# Altium schematic sheet IO.SchDoc(IO)
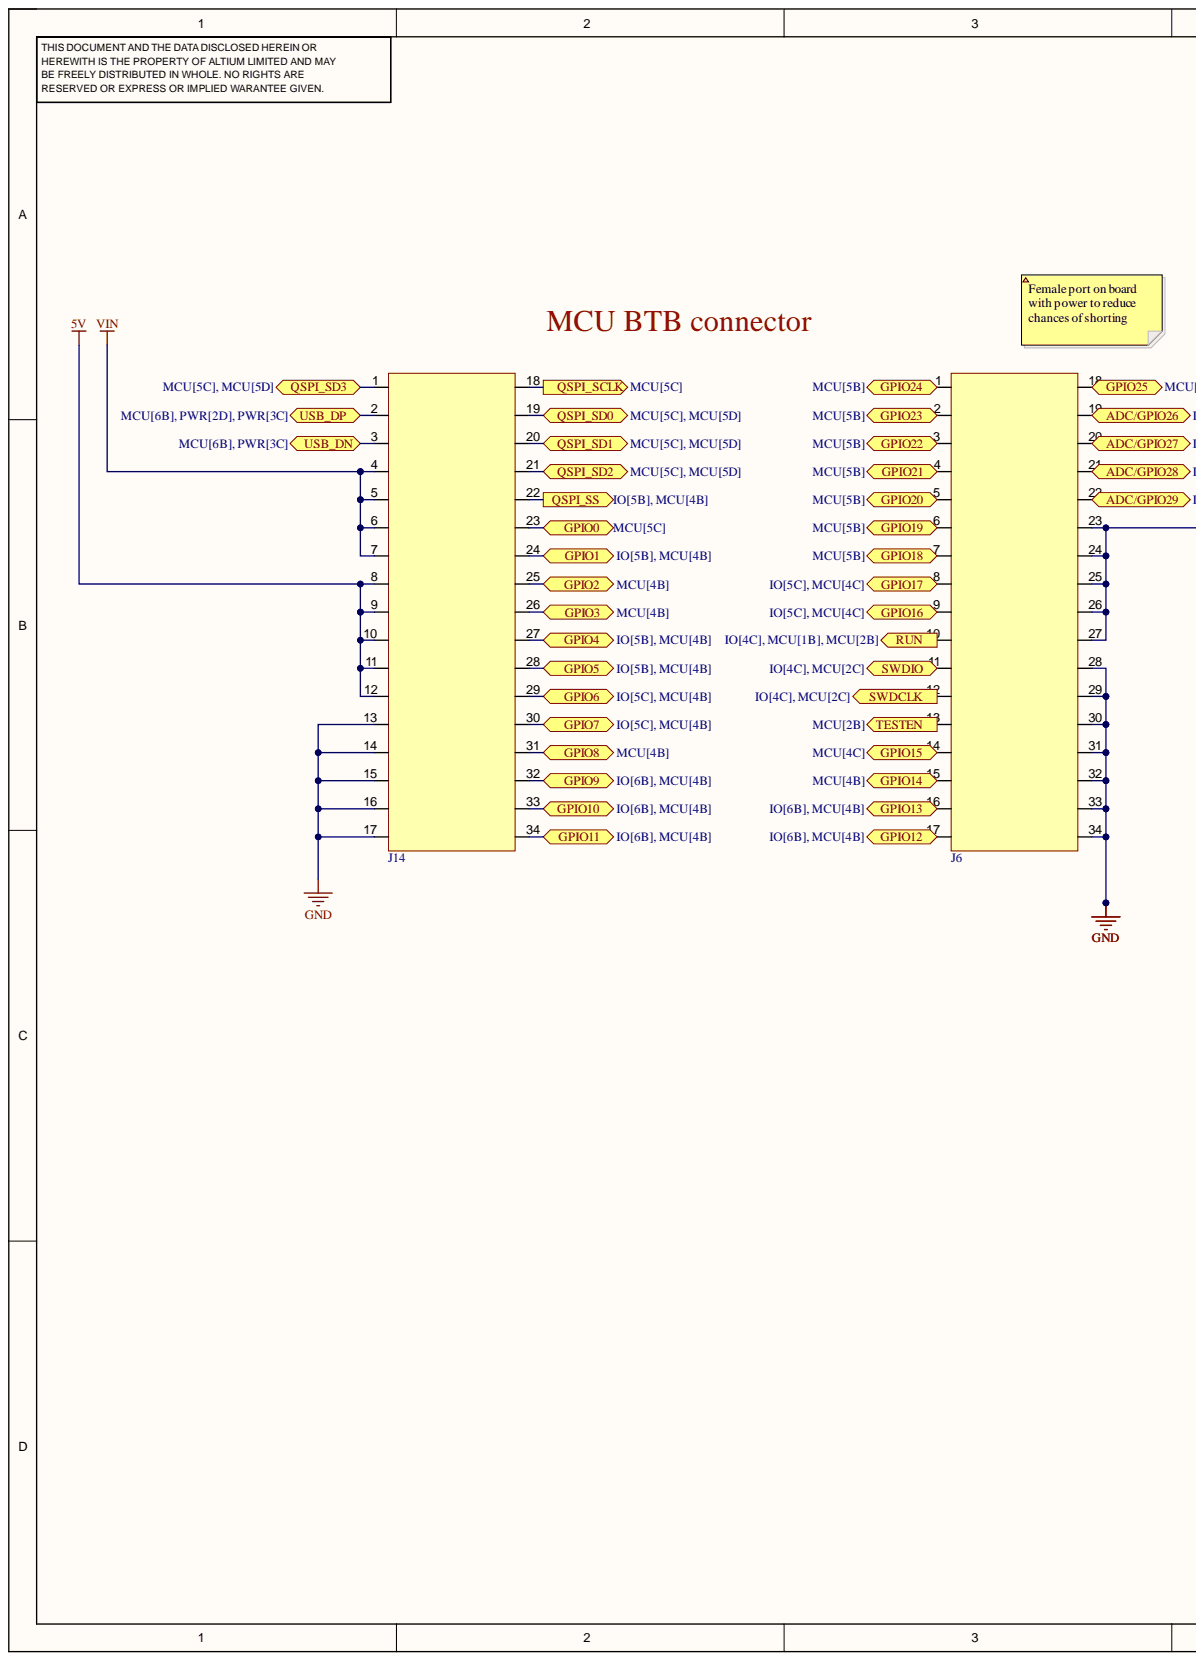
[diagram p0: IO.SchDoc, left]
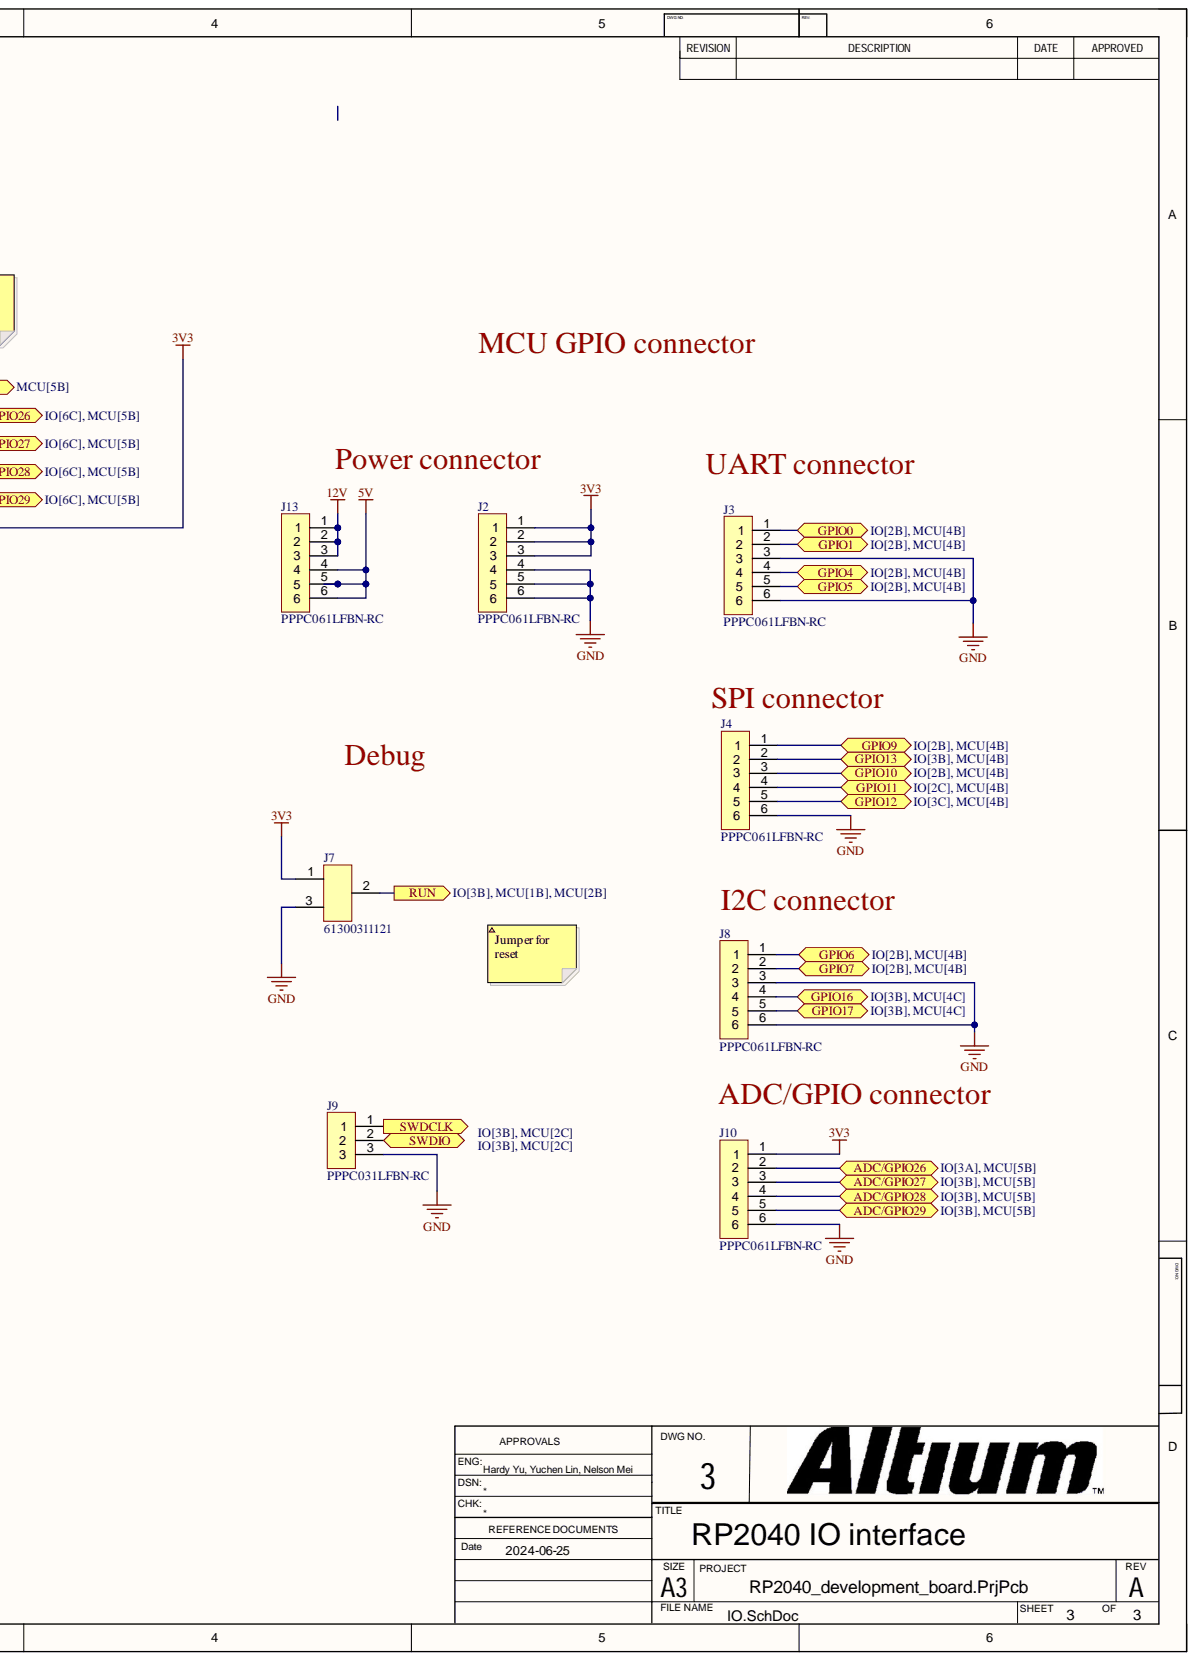
[diagram p1: IO.SchDoc, right]

## Parts
J2  PPPC061LFBN-RC  [PPPC061LFBN-RC-Footprint-1]
J3  PPPC061LFBN-RC  [PPPC061LFBN-RC-Footprint-1]
J4  PPPC061LFBN-RC  [PPPC061LFBN-RC-Footprint-1]
J6  5054133410  [CON_5054133410_MOL]  (value not drawn)
J7  61300311121  [61300311121]
J8  PPPC061LFBN-RC  [PPPC061LFBN-RC-Footprint-1]
J9  PPPC031LFBN-RC  [PPPC031LFBN-RC-Footprint-1]
J10  PPPC061LFBN-RC  [PPPC061LFBN-RC-Footprint-1]
J13  PPPC061LFBN-RC  [PPPC061LFBN-RC-Footprint-1]
J14  5054133410  [CON_5054133410_MOL]  (value not drawn)

## Ports
ADC/GPIO26, ADC/GPIO27, ADC/GPIO28, ADC/GPIO29, GPIO0, GPIO1, GPIO2, GPIO3, GPIO4, GPIO5, GPIO6, GPIO7, GPIO8, GPIO9, GPIO10, GPIO11, GPIO12, GPIO13, GPIO14, GPIO15, GPIO16, GPIO17, GPIO18, GPIO19, GPIO20, GPIO21, GPIO22, GPIO23, GPIO24, GPIO25, QSPI_SCLK, QSPI_SD0, QSPI_SD1, QSPI_SD2, QSPI_SD3, QSPI_SS, RUN, SWDCLK, SWDIO, TESTEN, USB_DN, USB_DP

## Nets
3V3: J2.1 J2.2 J2.3 J6.23 J6.24 J6.25 J6.26 J6.27 J7.1 J10.1
5V: J13.4 J13.5 J13.6 J14.8 J14.9 J14.10 J14.11 J14.12
12V: J13.1 J13.2 J13.3
GND: J2.4 J2.5 J2.6 J3.3 J3.6 J4.6 J6.28 J6.29 J6.30 J6.31 J6.32 J6.33 J6.34 J7.3 J8.3 J8.6 J9.3 J10.6 J14.13 J14.14 J14.15 J14.16 J14.17
VIN: J14.4 J14.5 J14.6 J14.7
ADC/GPIO26: J6.19 J10.2 ; port ADC/GPIO26
ADC/GPIO27: J6.20 J10.3 ; port ADC/GPIO27
ADC/GPIO28: J6.21 J10.4 ; port ADC/GPIO28
ADC/GPIO29: J6.22 J10.5 ; port ADC/GPIO29
GPIO0: J3.1 J14.23 ; port GPIO0
GPIO1: J3.2 J14.24 ; port GPIO1
GPIO2: J14.25 ; port GPIO2
GPIO3: J14.26 ; port GPIO3
GPIO4: J3.4 J14.27 ; port GPIO4
GPIO5: J3.5 J14.28 ; port GPIO5
GPIO6: J8.1 J14.29 ; port GPIO6
GPIO7: J8.2 J14.30 ; port GPIO7
GPIO8: J14.31 ; port GPIO8
GPIO9: J4.1 J14.32 ; port GPIO9
GPIO10: J4.3 J14.33 ; port GPIO10
GPIO11: J4.4 J14.34 ; port GPIO11
GPIO12: J4.5 J6.17 ; port GPIO12
GPIO13: J4.2 J6.16 ; port GPIO13
GPIO14: J6.15 ; port GPIO14
GPIO15: J6.14 ; port GPIO15
GPIO16: J6.9 J8.4 ; port GPIO16
GPIO17: J6.8 J8.5 ; port GPIO17
GPIO18: J6.7 ; port GPIO18
GPIO19: J6.6 ; port GPIO19
GPIO20: J6.5 ; port GPIO20
GPIO21: J6.4 ; port GPIO21
GPIO22: J6.3 ; port GPIO22
GPIO23: J6.2 ; port GPIO23
GPIO24: J6.1 ; port GPIO24
GPIO25: J6.18 ; port GPIO25
QSPI_SCLK: J14.18 ; port QSPI_SCLK
QSPI_SD0: J14.19 ; port QSPI_SD0
QSPI_SD1: J14.20 ; port QSPI_SD1
QSPI_SD2: J14.21 ; port QSPI_SD2
QSPI_SD3: J14.1 ; port QSPI_SD3
QSPI_SS: J14.22 ; port QSPI_SS
RUN: J6.10 J7.2 ; port RUN
SWDCLK: J6.12 J9.1 ; port SWDCLK
SWDIO: J6.11 J9.2 ; port SWDIO
TESTEN: J6.13 ; port TESTEN
USB_DN: J14.3 ; port USB_DN
USB_DP: J14.2 ; port USB_DP

## Library data of the parts
J2-J4 J8 J10 J13 = CMP-002-00004-4: CONN HEADER 6X1 2.54MM FEMALE VERT TH; Manufacturer: Sullins Connector Solutions; Component Kind: Standard; Manufacturer P/N: PPPC061LFBN-RC; Pitch: 2.54mm; Supplier: Digikey; Supplier Part Number: S7039-ND; Library Name: WARG
J6 J14 = 5054133410: 34 Position Connector Receptacle, Center Strip Contacts Surface Mount Gold; Manufacture: Molex; # of rows: 2; Component Kind: Standard; ComponentLink1Description: digitkey; ComponentLink1URL: https://www.digikey.ca/en/products/detail/molex/5054133410/6822630; Library Name: RP2040_dev_board.SchLib
J7 = 61300311121: CONN HEADER VERT 3POS 2.54MM; Number of positions: 3; Component Kind: Standard; Connector Type: Header; Contact Type: Male Pin; Library Name: RP2040_dev_board.SchLib
J9 = CMP-002-00001-4: CONN HEADER 3X1 2.54MM FEMALE VERT TH; Manufacturer: Sullins Connector Solutions; Component Kind: Standard; Manufacturer P/N: PPPC031LFBN-RC; Pitch: 2.54mm; Supplier: Digikey; Supplier Part Number: S7036-ND; Library Name: WARG
